# Altium schematic sheet ETHERNET.SchDoc
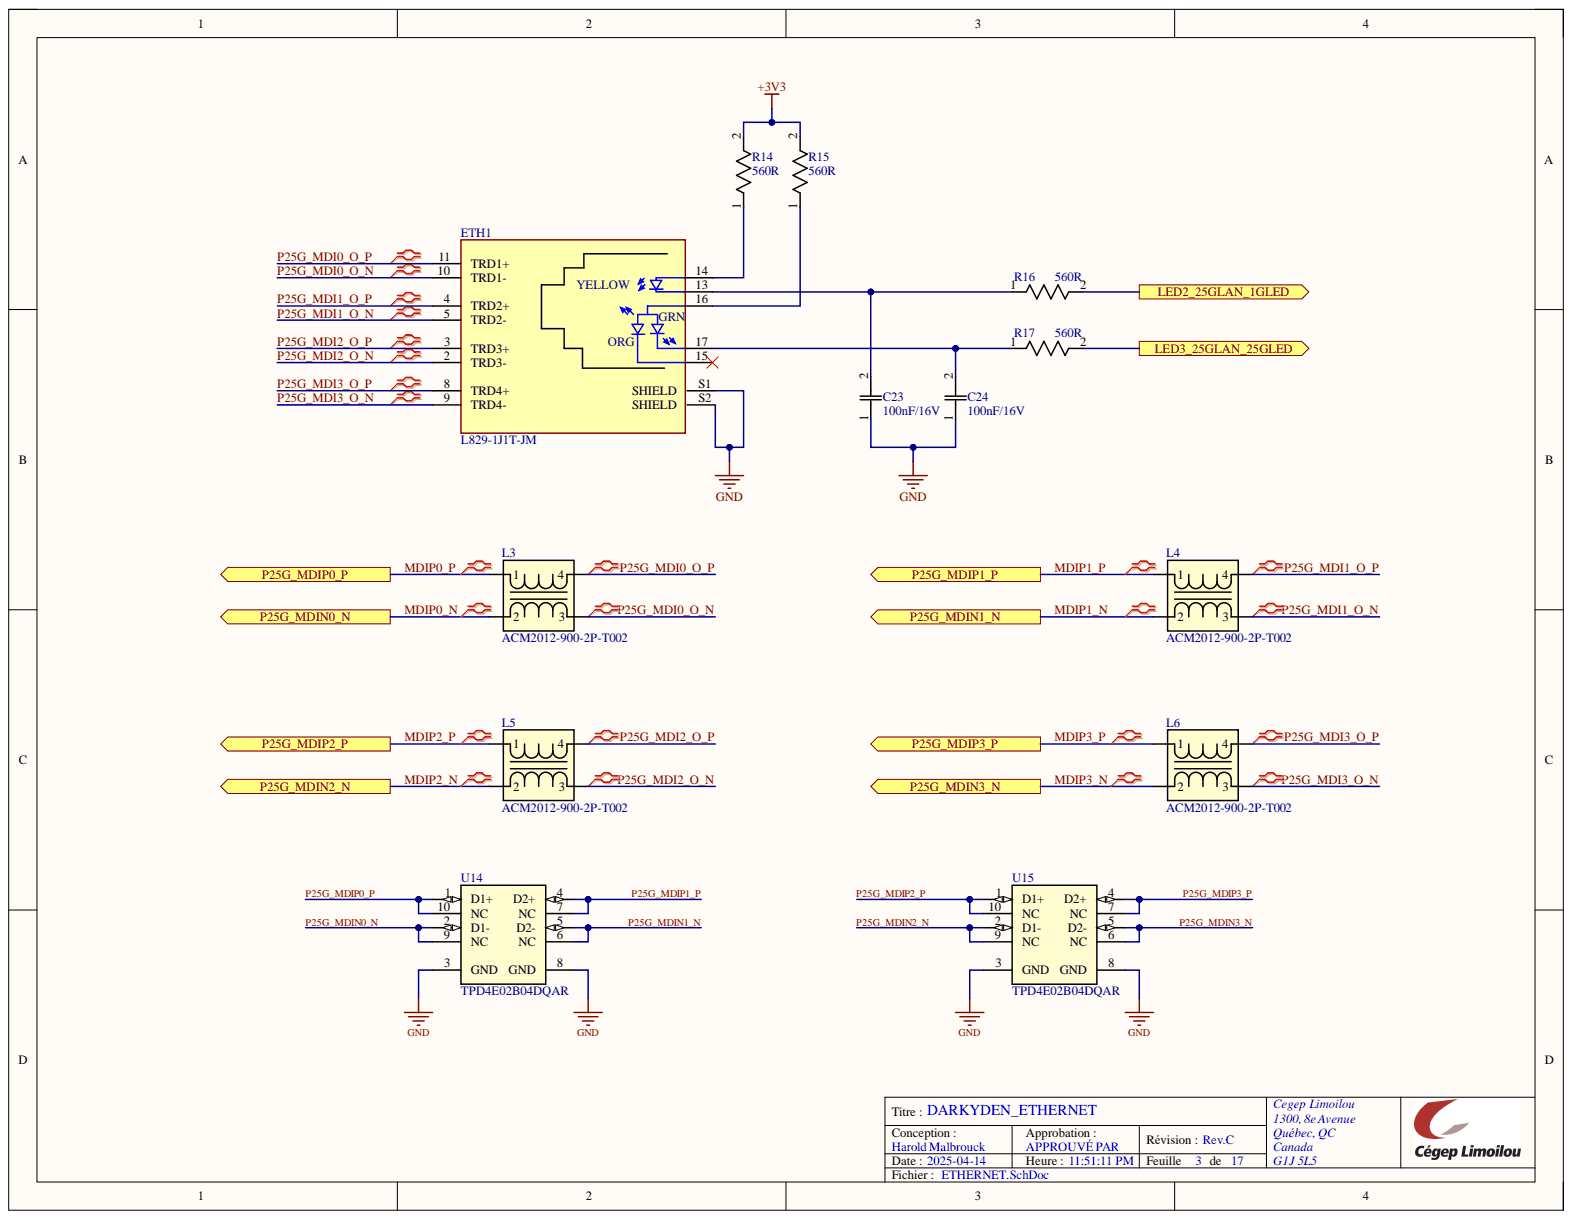
[diagram p0: ETHERNET.SchDoc]

## Parts
C23  100nF/16V  [CAP_CC0805_YAG]
C24  100nF/16V  [CAP_CC0805_YAG]
ETH1  L829-1J1T-JM  [BEL_L829-1J1T-JM]
L3  ACM2012-900-2P-T002  [ACM2012_TDK]
L4  ACM2012-900-2P-T002  [ACM2012_TDK]
L5  ACM2012-900-2P-T002  [ACM2012_TDK]
L6  ACM2012-900-2P-T002  [ACM2012_TDK]
R14  560R  [RES_0816_SUS]
R15  560R  [RES_0816_SUS]
R16  560R  [RES_0816_SUS]
R17  560R  [RES_0816_SUS]
U14  TPD4E02B04DQAR  [DQA0010A]
U15  TPD4E02B04DQAR  [DQA0010A]

## Ports
LED2_25GLAN_1GLED, LED3_25GLAN_25GLED, P25G_MDIN0_N, P25G_MDIN1_N, P25G_MDIN2_N, P25G_MDIN3_N, P25G_MDIP0_P, P25G_MDIP1_P, P25G_MDIP2_P, P25G_MDIP3_P

## Nets
+3V3: R14.2 R15.2
GND: C23.1 C24.1 ETH1.S1(SHIELD) ETH1.S2(SHIELD) U14.3(GND) U14.8(GND) U15.3(GND) U15.8(GND)
LED2_25GLAN_1GLED: R16.2 ; port LED2_25GLAN_1GLED
LED3_25GLAN_25GLED: R17.2 ; port LED3_25GLAN_25GLED
MDIP0_N: L3.2 ; port P25G_MDIN0_N
MDIP0_P: L3.1 ; port P25G_MDIP0_P
MDIP1_N: L4.2 ; port P25G_MDIN1_N
MDIP1_P: L4.1 ; port P25G_MDIP1_P
MDIP2_N: L5.2 ; port P25G_MDIN2_N
MDIP2_P: L5.1 ; port P25G_MDIP2_P
MDIP3_N: L6.2 ; port P25G_MDIN3_N
MDIP3_P: L6.1 ; port P25G_MDIP3_P
P25G_MDI0_O_N: ETH1.10(TRD1-) L3.3
P25G_MDI0_O_P: ETH1.11(TRD1+) L3.4
P25G_MDI1_O_N: ETH1.5(TRD2-) L4.3
P25G_MDI1_O_P: ETH1.4(TRD2+) L4.4
P25G_MDI2_O_N: ETH1.2(TRD3-) L5.3
P25G_MDI2_O_P: ETH1.3(TRD3+) L5.4
P25G_MDI3_O_N: ETH1.9(TRD4-) L6.3
P25G_MDI3_O_P: ETH1.8(TRD4+) L6.4
P25G_MDIN0_N: U14.2(D1-) U14.9(NC)
P25G_MDIN1_N: U14.5(D2-) U14.6(NC)
P25G_MDIN2_N: U15.2(D1-) U15.9(NC)
P25G_MDIN3_N: U15.5(D2-) U15.6(NC)
P25G_MDIP0_P: U14.1(D1+) U14.10(NC)
P25G_MDIP1_P: U14.4(D2+) U14.7(NC)
P25G_MDIP2_P: U15.1(D1+) U15.10(NC)
P25G_MDIP3_P: U15.4(D2+) U15.7(NC)
NetC23_2: C23.2 ETH1.13 R16.1
NetC24_2: C24.2 ETH1.17 R17.1
NetETH1_14: ETH1.14 R14.1
NetETH1_16: ETH1.16 R15.1

Not connected: ETH1 15

## Library data of the parts
C23 C24 = Capacitor_0805: No Description Available; Mfr_Name: Yageo; Component Kind: Standard; Library Name: Capacitor_0805.SchLib
ETH1 = L829-1J1T-JM: MagJack 2.5GBASE-T | 1X1 | OG/Y LED | -40 to 85C | RoHS; MF: Bel Magnetic Solutions; Component Kind: Standard; Digi-Key_Part_Number: 507-2068-ND; DigiKey-Purchase-URL: https://snapeda.com/shop?store=DigiKey&id=2758678; Library Name: L829-1J1T-JM.SchLib; MP: L829-1J1T-JM; Package: None; SnapEDA_Link: https://www.snapeda.com/parts/L829-1J1T-JM/Bel%20Magnetic%20Solutions/view-part/2758678/?ref=snap
L3-L6 = ACM2012-900-2P-T002: No Description Available; Mfr_Name: TDK; Component Kind: Standard; Library Name: ACM2012.SchLib
R14-R17 = RG1608P-104-B-T5: No Description Available; Mfr_Name: Susumu; Component Kind: Standard; Library Name: RES_SMD_0603.SchLib
U14 U15 = TPD4E02B04DQAR: No Description Available; Manufacturer: Texas Instruments; CompType: IC; Component Kind: Standard; Library Name: TPD4E02B04DQAR.SchLib; Mfr_Name: Texas Instruments; MountType: SMT; PackageReference: DQA0010A; PartNumber: TPD4E02B04DQAR; RefDes: RefDes; RoHS: Y; TYPE: TYPE
